# Altium schematic sheet Headers.SchDoc(Headers)
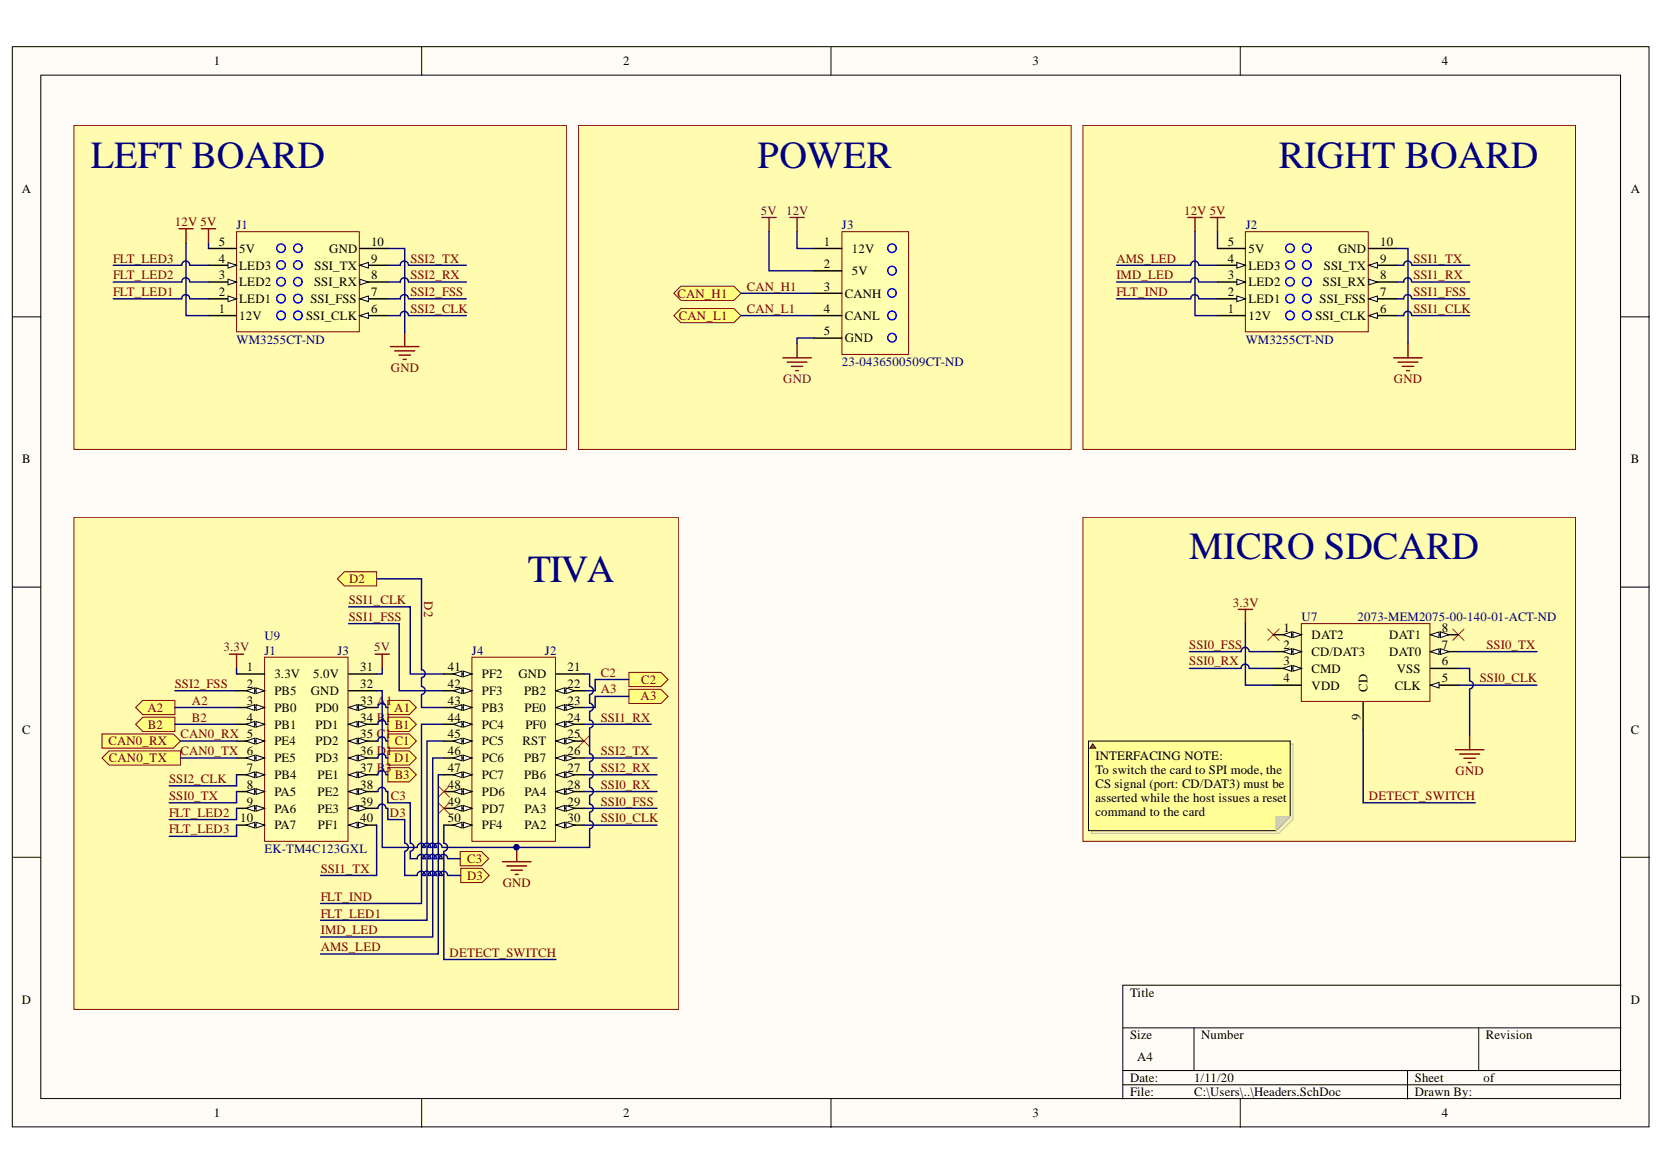
[diagram p0: Headers.SchDoc]

## Parts
J1  WM3255CT-ND  [MOLEX-10PIN]
J2  WM3255CT-ND  [MOLEX-10PIN]
J3  23-0436500509CT-ND  [MOLEX-5PIN]
U7  2073-MEM2075-00-140-01-ACT-ND  [SDCARD-NORMAL]
U9  EK-TM4C123GXL  [TIVA Headers]

## Ports
A1, A2, A3, B1, B2, B3, C1, C2, C3, CAN0_RX, CAN0_TX, CAN_H1, CAN_L1, D1, D2, D3

## Nets
3.3V: U7.4(VDD) U9.1(3.3V)
5V: J1.5(5V) J2.5(5V) J3.2(5V) U9.31
12V: J1.1(12V) J2.1(12V) J3.1(12V)
GND: J1.10(GND) J2.10(GND) J3.5(GND) U7.6(VSS) U9.21(GND) U9.32
A1: U9.33(A1) ; port A1
A2: U9.3(PB0) ; port A2
A3: U9.23(PE0) ; port A3
AMS_LED: J2.4(LED3) U9.47
B1: U9.34(B1) ; port B1
B2: U9.4(PB1) ; port B2
B3: U9.37(B3) ; port B3
C1: U9.35(C1) ; port C1
C2: U9.22(PB2) ; port C2
C3: U9.38(C3) ; port C3
CAN0_RX: U9.5(PE4) ; port CAN0_RX
CAN0_TX: U9.6(PE5) ; port CAN0_TX
CAN_H1: J3.3(CANH) ; port CAN_H1
CAN_L1: J3.4(CANL) ; port CAN_L1
D1: U9.36(D1) ; port D1
D2: U9.43 ; port D2
D3: U9.39 ; port D3
DETECT_SWITCH: U7.9(CD) U9.50
FLT_IND: J2.2(LED1) U9.44
FLT_LED1: J1.2(LED1) U9.45
FLT_LED2: J1.3(LED2) U9.9(PA6)
FLT_LED3: J1.4(LED3) U9.10(PA7)
IMD_LED: J2.3(LED2) U9.46
SSI0_CLK: U7.5(CLK) U9.30(PA2)
SSI0_FSS: U7.2(CD/DAT3) U9.29(PA3)
SSI0_RX: U7.3(CMD) U9.28(PA4)
SSI0_TX: U7.7(DAT0) U9.8(PA5)
SSI1_CLK: J2.6(SSI_CLK) U9.41
SSI1_FSS: J2.7(SSI_FSS) U9.42
SSI1_RX: J2.8(SSI_RX) U9.24(PF0)
SSI1_TX: J2.9(SSI_TX) U9.40
SSI2_CLK: J1.6(SSI_CLK) U9.7(PB4)
SSI2_FSS: J1.7(SSI_FSS) U9.2(PB5)
SSI2_RX: J1.8(SSI_RX) U9.27(PB6)
SSI2_TX: J1.9(SSI_TX) U9.26(PB7)

Not connected: U7 1(DAT2) 8(DAT1); U9 25(RST) 48 49

## Library data of the parts
J1 J2 = MOLEX-10PIN: HEADER R/A 10POS DUAL TIN SMD; Manufacturer Part Number: 0430451006; Manufacturer: Molex; Row Spacing: 0.118inch; Pitch: 3mm; Number of Positions: 10; Contact Resistance: 10mR; Connector Type: Male Pin; Termination: Solder; Supplier Part Number 1: WM3255CT-ND; Supplier 1: Digi-Key; Stock 1: 2460; RoHS: Yes; Pricing 1: 1=3.72000, 10=3.57200, 100=2.97680 (USD); Packaging: Tape and Reel; Orientation: Right Angle; Number of Rows: 2; Number of Contacts: 10; Mount: Surface Mount; Min Operating Temperature: -40°C; Max Operating Temperature: 105°C; Length: 19.15mm; Housing Material: Polymer; Housing Color: Black; Fastening Type: Latch; Depth: 15.4mm; Contact Plating: Tin; Contact Material: Brass; ComponentLink1URL: https://datasheet.ciiva.com/737/430450407-sd-737953.pdf?src-supplier=Digi-Key; ComponentLink1Description: https://datasheet.ciiva.com/737/430450407-sd-737953.pdf?src-supplier=Digi-Key; Component Kind: Standard; Color: Black; Library Name: Dashboard.SchLib
J3 = 0436500509: GLOVE, POLYAMIDE, L/FREE, PU, SIZE 6 - More Details; Manufacturer: Molex; Voltage Rating (DC): 250V; Voltage Rating: 600V; Pitch: 3mm; Number of Positions: 5; Glow Wire Compliant: Compliant; Gender: Male; Current Rating: 5A; Connector Type: Male Pin; Termination: Solder; Supplier Part Number 1: 23-0436500509CT-ND; Supplier 1: Digi-Key; Stock 1: 8420; RoHS: Yes; Pricing 1: 1=2.58000, 10=2.34500, 100=2.00970 (USD); Packaging: Tape and Reel; Package Quantity: 10; Orientation: Right Angle; Number of Rows: 1; Number of Contacts: 5; Number of Circuits: 5; Mount: Surface Mount; Min Operating Temperature: -40°C; Max Voltage Rating (AC): 250V; Max Operating Temperature: 105°C; Manufacturer Part Number: 0436500509; Housing Material: Polymer; Housing Color: Black; Halogen Free: Low Halogen; Fastening Type: Ramp; Contact Plating: Tin; Contact Material: Brass; Contact Gender: Male; ComponentLink2URL: https://datasheet.ciiva.com/16089/0436500509-pcb-headers-16089055.pdf?src-supplier=Onlinecomponents.com; ComponentLink2Description: https://datasheet.ciiva.com/16089/0436500509-pcb-headers-16089055.pdf?src-supplier=Onlinecomponents.com; ComponentLink1URL: https://datasheet.ciiva.com/712/436501209-sd-712211.pdf?src-supplier=Digi-Key; ComponentLink1Description: https://datasheet.ciiva.com/712/436501209-sd-712211.pdf?src-supplier=Digi-Key; Component Kind: Standard; Color: Black; Library Name: Dashboard.SchLib
U7 = SDCARD CONN.: 850NM POINT SOURCE LED ASSY; Manufacturer: Global Connector Technology; Supplier Part Number 1: 56AC1963; Supplier 1: Newark; Stock 1: 1028; RoHS: No; Pricing 1: 1=1.68000, 10=1.53000, 25=1.53000, 50=1.53000, 100=1.42000, 250=1.42000, 500=1.32000, 1000=1.23000, 1=1.26000, 10=1.15000, 25=1.15000, 50=1.15000, 100=1.07000, 250=1.07000, 500=0.98600, 1000=0.92000 (CAD); Manufacturer Part Number: MEM2075-00-140-01-A; Component Kind: Standard; Library Name: Dashboard.SchLib
U9 = TIVA Headers: Component Kind: Standard; Library Name: Dashboard.SchLib
